# Altium schematic sheet NXP NXPhlite-Power 3.0RC18.SchDoc
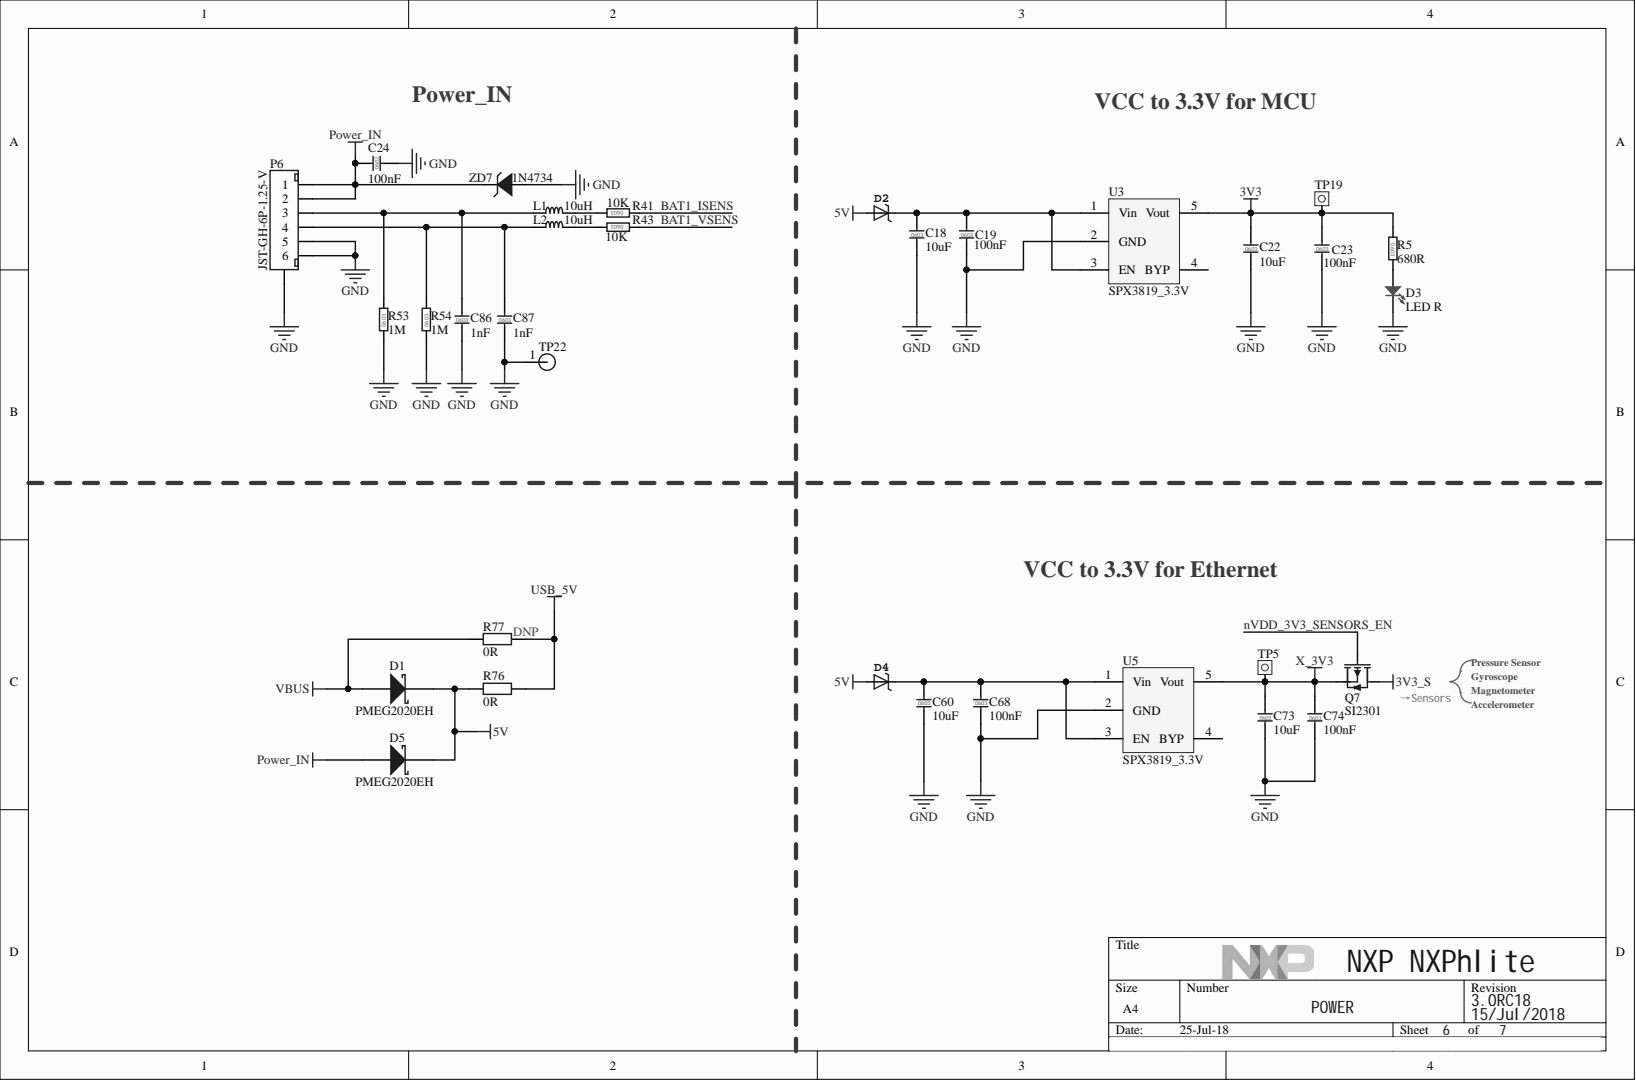
[diagram p0: NXP NXPhlite-Power 3.0RC18.SchDoc]

## Parts
C18  10uF  [0603C]
C19  100nF  [0603C]
C22  10uF  [0603C]
C23  100nF  [0603C]
C24  100nF  [0603C]
C60  10uF  [0603C]
C68  100nF  [0603C]
C73  10uF  [0603C]
C74  100nF  [0603C]
C86  1nF  [0603C]
C87  1nF  [0603C]
D1  PMEG2020EH  [SMA]
D2  DFSL130L-7  [POWERDI-123_3MMX2MM]  (value not drawn)
D3  LED R  [0603LED_ºì]
D4  DFSL130L-7  [POWERDI-123_3MMX2MM]  (value not drawn)
D5  PMEG2020EH  [SMA]
L1  10uH  [0603L]
L2  10uH  [0603L]
P6  JST-GH-6P-1.25-V  [JST-6P-1.25]
Q7  SI2301  [SOT-23]
R5  680R  [0603R]
R41  10K  [0603R]
R43  10K  [0603R]
R53  1M  [0603R]
R54  1M  [0603R]
R76  0R  [0603R]
R77  0R  [0603R]
TP5  TP  [TP_0.8]
TP19  TP  [TP_0.8]
TP22  TP  [5013_testpoint]
U3  SPX3819_3.3V  [SOT-23-5]
U5  SPX3819_3.3V  [SOT-23-5]
ZD7  1N4734  [SMA]

## Nets
3V3: C22.1 C23.1 R5.2 TP19.1 U3.5(Vout)
3V3_S: Q7.3
5V: D1.2 D2.A D4.A D5.2 R76.1
GND: C18.2 C19.2 C22.2 C23.2 C24.2 C60.2 C68.2 C73.2 C74.2 C86.2 C87.2 D3.2 P6.0 P6.5 P6.6 R53.1 R54.1 TP22.1 U3.2(GND) U5.2(GND) ZD7.1
Power_IN: C24.1 D5.1 P6.1 P6.2 ZD7.2
USB_5V: R76.2 R77.2
VBUS: D1.1 R77.1
X_3V3: C73.1 C74.1 Q7.2 TP5.1 U5.5(Vout)
BAT1_ISENS: R41.2
BAT1_VSENS: R43.2
nVDD_3V3_SENSORS_EN: Q7.1
NetC18_1: C18.1 C19.1 D2.C U3.1(Vin) U3.3(EN)
NetC60_1: C60.1 C68.1 D4.C U5.1(Vin) U5.3(EN)
NetC86_1: C86.1 L1.1 P6.3 R53.2
NetC87_1: C87.1 L2.1 P6.4 R54.2
NetD3_1: D3.1 R5.1
NetL1_2: L1.2 R41.1
NetL2_2: L2.2 R43.1

Not connected: U3 4(BYP); U5 4(BYP)

## Library data of the parts
C18 C22 C60 C73 = Cap_0603: CAP CER 10.0uF 16V 10% X5R 0603; Manufacturer: MURATA; Manufacturer PN: GRM188R61C106KAALD; Agile PN: 150-80060; Component Kind: Standard; Library Name: LZ SchLib V1.0.SchLib
C19 C23 C24 C68 C74 = Cap_0603: CAP CER 0.1uF 16V 10% X7R AEC-Q200 0603; Manufacturer: MURATA; Manufacturer PN: GCM188R71C104KA37D; Agile PN: 150-79338; Component Kind: Standard; Library Name: LZ SchLib V1.0.SchLib
C86 C87 = Cap_0603: CAP CER 1000pF 25V 10% X7R 0603; Manufacturer: Yageo; Manufacturer PN: CC0603KRX7R8BB102; Agile PN: 150-78736; Component Kind: Standard; Library Name: LZ SchLib V1.0.SchLib
D1 D5 = Diode 10TQ035: DIODE SCHOTTKY 20V 2A SOD123F; Manufacturer: Nexperia; Agile PN: TBD; Component Kind: Standard; Library Name: Miscellaneous Devices.IntLib; Manufacturer PN: PMEG2020EH; Simulation: 10TQ035
D2 D4 = DIODE-SCHOTTKY_MSMP: DIODE SCH 1A 30V PowerDI123; Manufacturer: DIODES INC; Manufacturer PN: DFLS130L-7; Agile PN: 480-78285; Component Kind: Standard; Library Name: LZFMU V2.0.SCHLIB
D3 = LED R: LED RED SGL 25MA 0603; Manufacturer: DIALIGHT; Manufacturer PN: 598-8010-107F; Agile PN: 370-76658; Component Kind: Standard; Library Name: LZ SchLib V1.0.SchLib
L1 L2 = Inductor_1: FIXED IND 10UH 10MA 1.7 OHM SMD; Manufacturer: TDK; Manufacturer PN: MLF1608E100JTD25; Agile PN: TBD; Component Kind: Standard; Library Name: LZ SchLib V1.0.SchLib
P6 = XH2.54_6P: CONN HEADER GH TOP 6POS 1.25MM; Manufacturer: JST Sales America Inc; Manufacturer PN: BM06B-GHS-TBT(LF)(SN)(N); Agile PN: TBD; Component Kind: Standard; Library Name: LANDZO flight controller V1.1.SCHLIB
Q7 = MOSFET-P: MOSFET P-CH 20V 3.1A SOT23-3; Manufacturer: VISHAY INTERTECHNOLOGY; Manufacturer PN: Si2301CDS; Agile PN: TBD; Component Kind: Standard; Simulation: PMOS; Library Name: NXP NXPhlite V3.2.SCHLIB
R5 = Res_0603: RES MF 680 OHM 1/8W 1% AEC-Q200 0603; Manufacturer: KOA SPEER; Manufacturer PN: RK73H1JTTD6800F; Agile PN: 470-81586; Component Kind: Standard; Library Name: LZ SchLib V1.0.SchLib
R41 R43 = Res_0603: RES MF 10.0K 1/10W 1% 0603; Manufacturer: Yageo; Manufacturer PN: RC0603FR-0710KL; Agile PN: 470-75422; Component Kind: Standard; Library Name: LZ SchLib V1.0.SchLib
R53 R54 = Res_0603: RES MF 1M 1/10W 1% 0603; Manufacturer: Yageo; Manufacturer PN: RC0603FR-071ML; Agile PN: 470-81306; Component Kind: Standard; Library Name: LZ SchLib V1.0.SchLib
R76 = Res: RES MF ZERO OHM 1/10W -- AEC-Q200 0603; Manufacturer: KOA SPEER; Manufacturer PN: RK73Z1JTTD; Agile PN: 470-75407; Component Kind: Standard; Library Name: SchLib.SchLib
R77 = Res: Resistor; Component Kind: Standard; Library Name: SchLib.SchLib
TP22 = 5013_TESTPOINT: TEST POINT PC MULTI PURPOSE ORG; Manufacturer: Keystone Electronics; Manufacturer PN: 5013; Agile PN: TBD; Component Kind: Standard; Library Name: NXP NXPhlite V3.2.SCHLIB
U3 U5 = SPX3819: IC VREG LDO 3.3V 500MA 2.5-16V SOT-23-5; Manufacturer: EXAR; Manufacturer PN: SPX3819M5-L-3-3; Agile PN: 315-79574; Component Kind: Standard; Library Name: Schlib1.SchLib
ZD7 = Diode TVS1: DIODE ZENER 5.6V 1W SOD123; Manufacturer: Micro Commercial Components; Manufacturer PN: 1N4734AW-TP; Agile PN: TBD; Component Kind: Standard; Library Name: LZ SchLib V1.0.SchLib
TP5 TP19 = TP: Component Kind: Standard; Library Name: LZ SchLib V1.0.SchLib
